# Altium schematic sheet carrier board Ultrafiltrasi.SchDoc
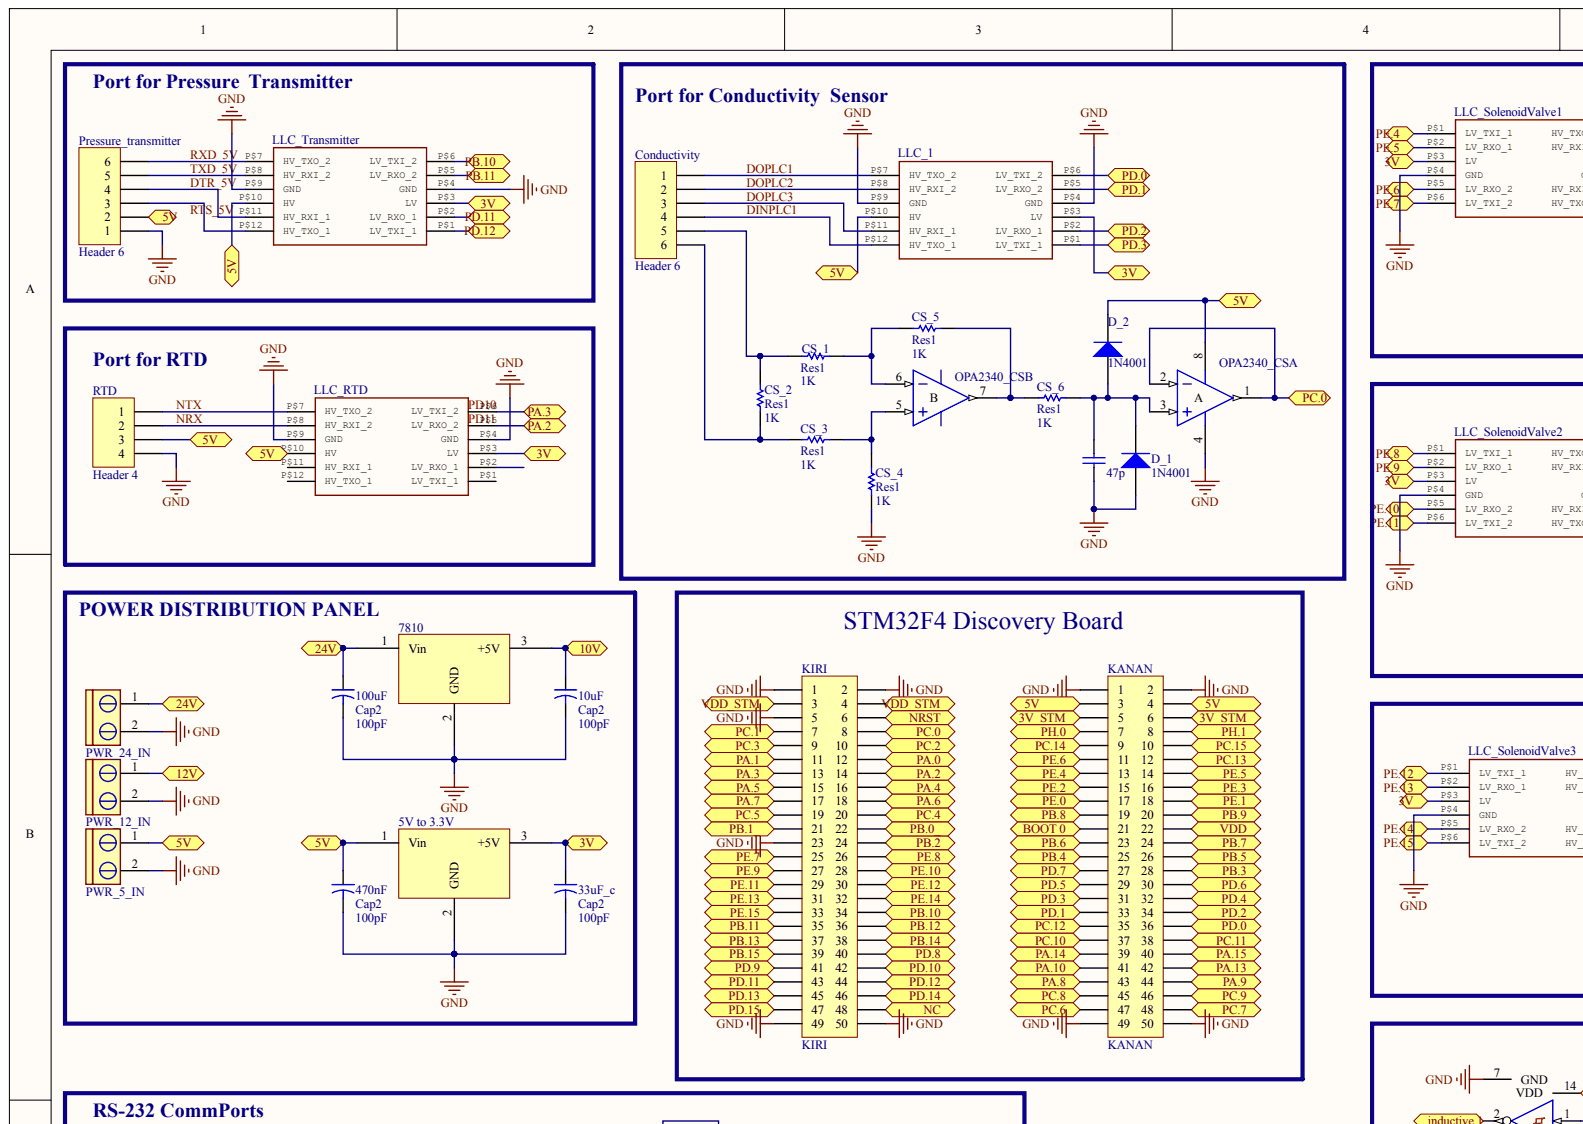
[diagram p0: carrier board Ultrafiltrasi.SchDoc, top left]
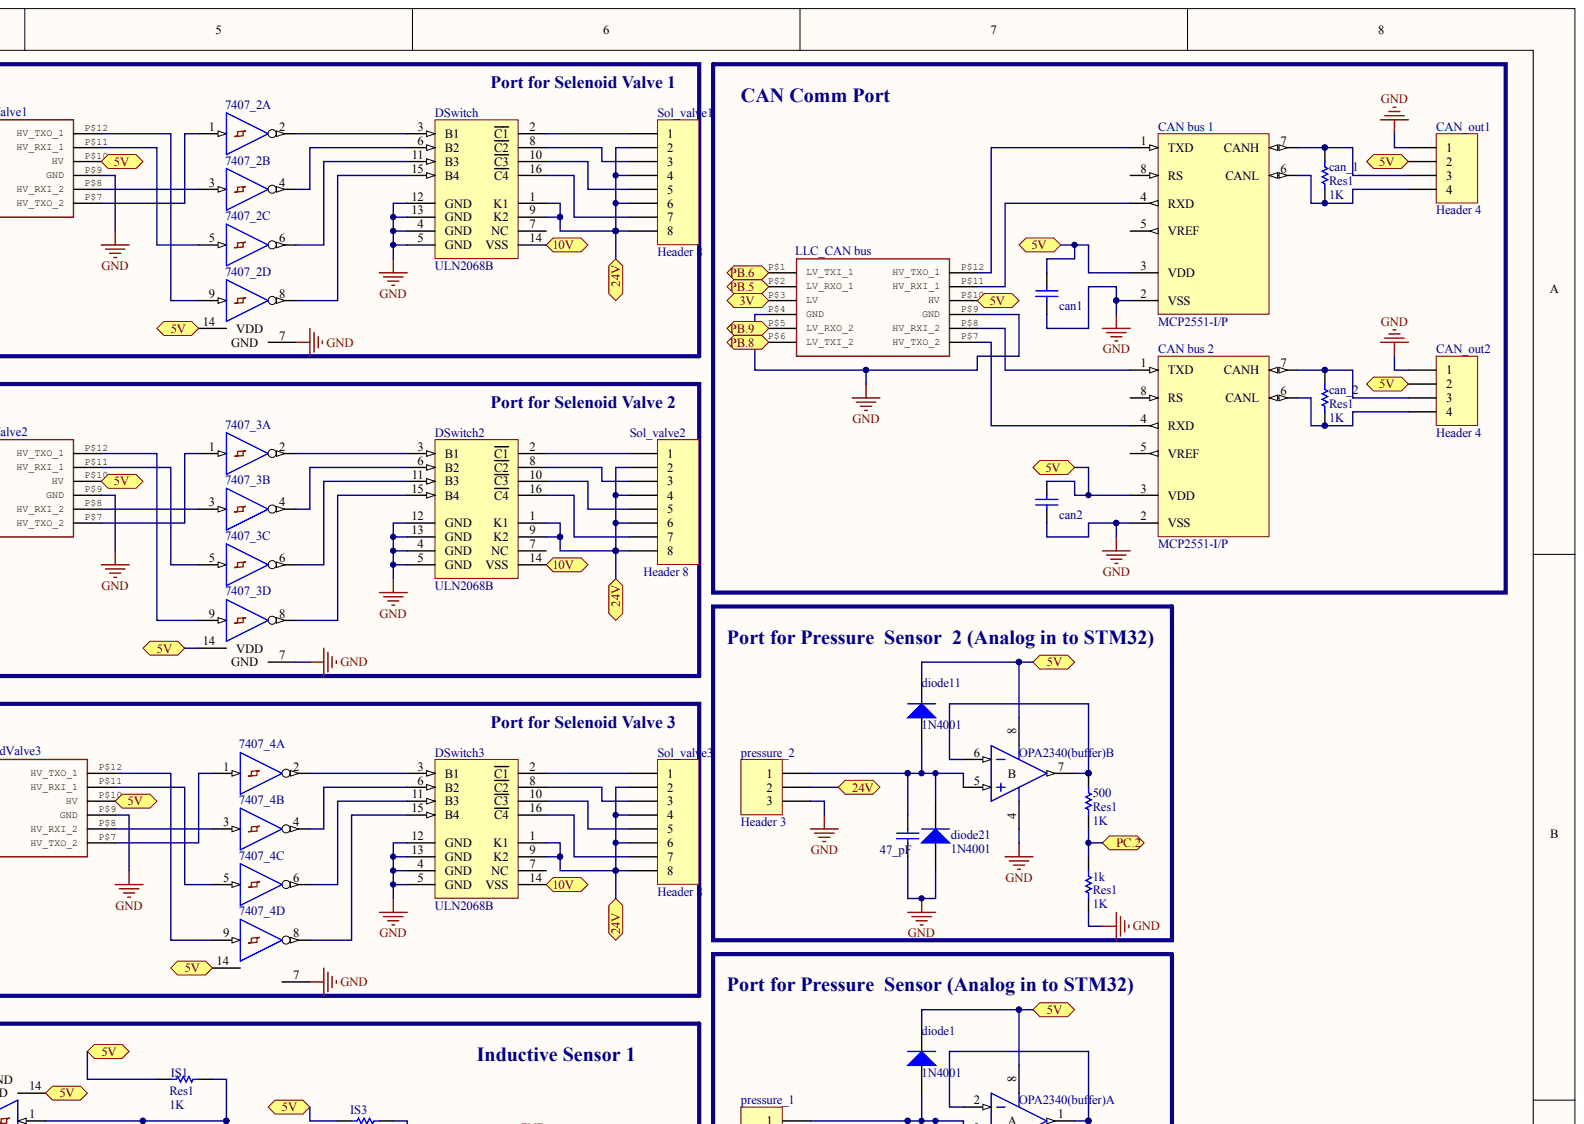
[diagram p1: carrier board Ultrafiltrasi.SchDoc, top right]
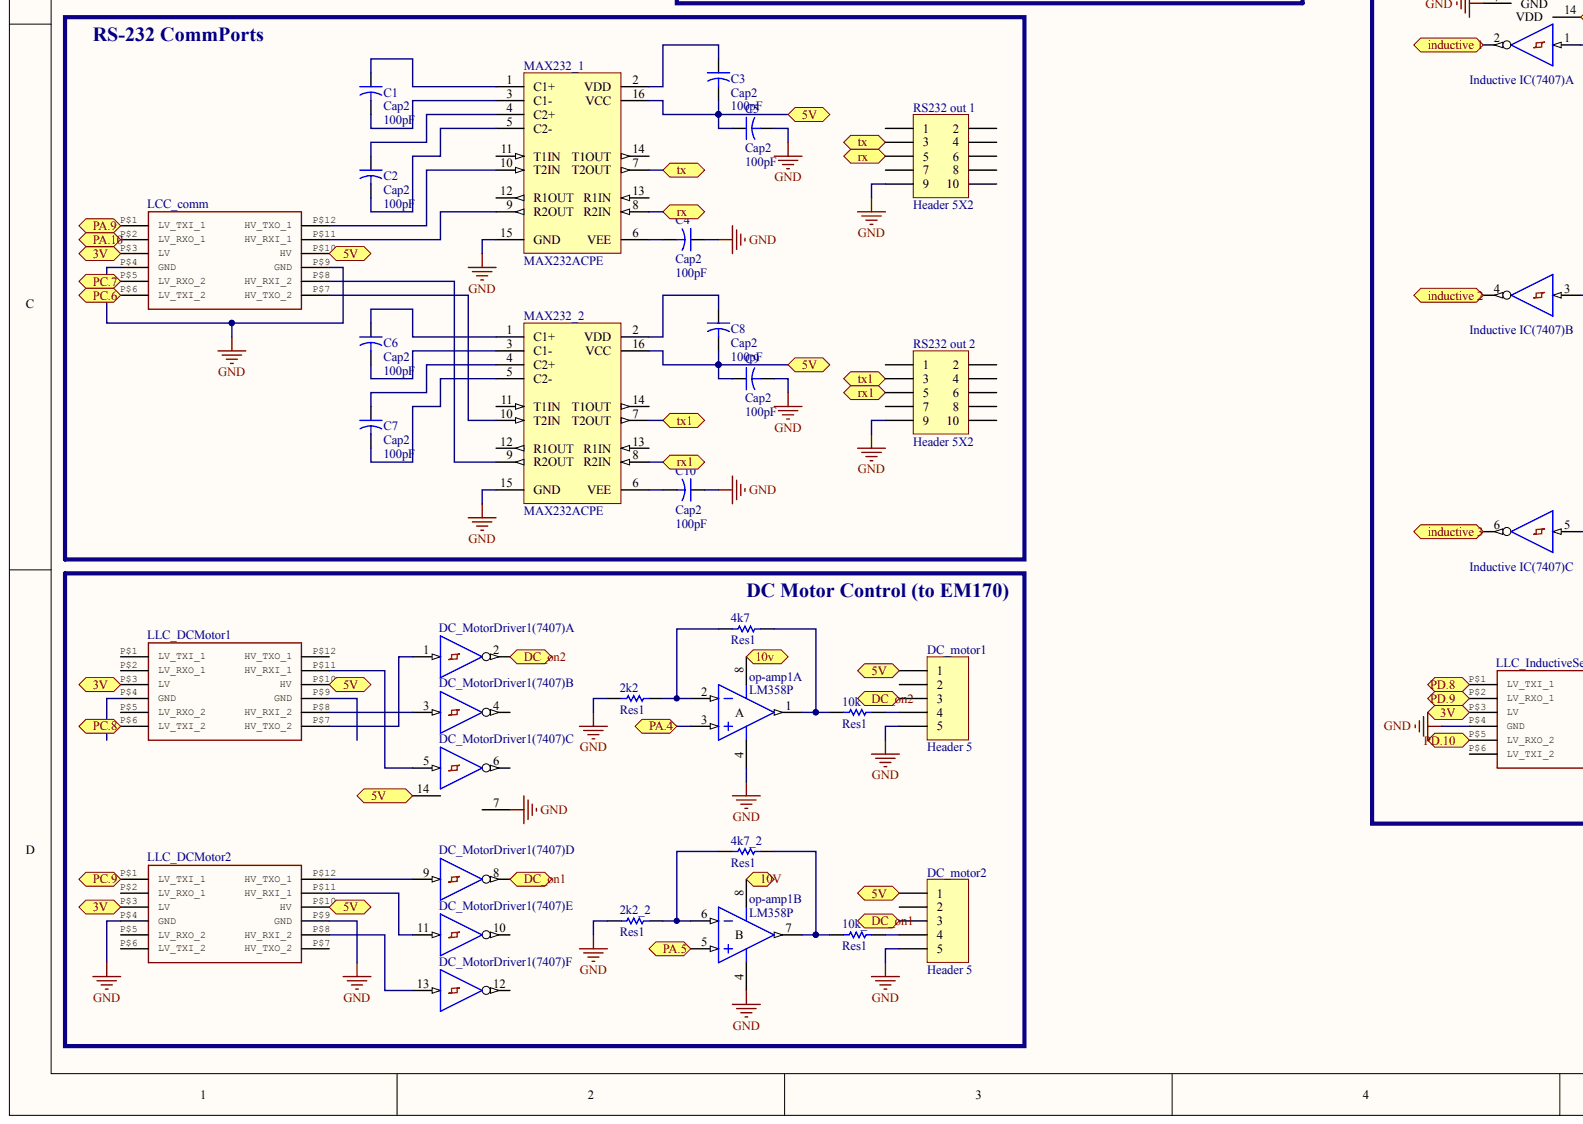
[diagram p2: carrier board Ultrafiltrasi.SchDoc, bottom left]
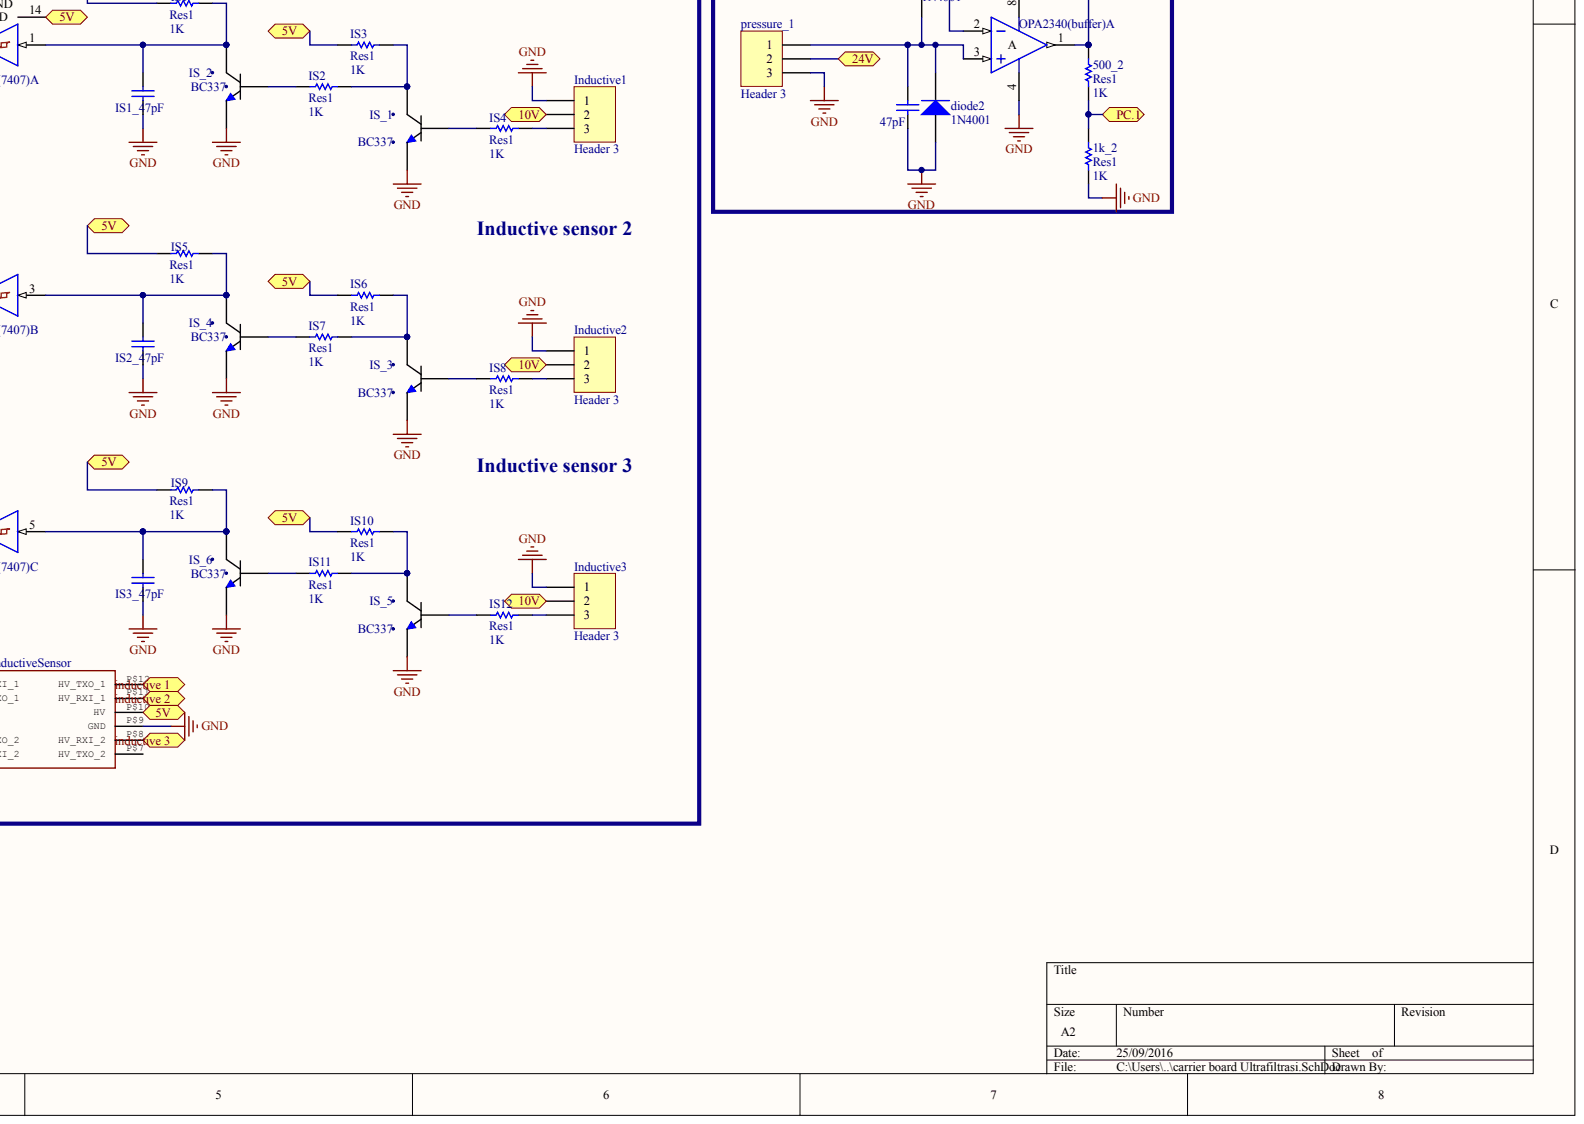
[diagram p3: carrier board Ultrafiltrasi.SchDoc, bottom right]

## Parts
1k  Res1  value 1K
1k_2  Res1  value 1K
2k2  Res1
2k2_2  Res1
4k7  Res1
4k7_2  Res1
5V_to_3.3V  7805  (value not drawn)
10k  Res1  value 10k
10k_2  Res1
10uF  Cap2  value 100pF
33uF_c  Cap2  value 100pF
47_pF  Cap  (value not drawn)
47p  Cap  (value not drawn)
47pF  Cap  (value not drawn)
100uF  Cap2  value 100pF
470nF  Cap2  value 100pF
500  Res1  value 1K
500_2  Res1  value 1K
7407_2  7407  (parts A,B,C,D)
7407_3  7407  (parts A,B,C,D)
7407_4  7407  (parts A,B,C,D)
7810  7805  (value not drawn)
C1  Cap2  value 100pF
C2  Cap2  value 100pF
C3  Cap2  value 100pF
C4  Cap2  value 100pF
C5  Cap2  value 100pF
C6  Cap2  value 100pF
C7  Cap2  value 100pF
C8  Cap2  value 100pF
C9  Cap2  value 100pF
C10  Cap2  value 100pF
can1  Cap  (value not drawn)
can2  Cap  (value not drawn)
CAN_bus_1  MCP2551-I/P
CAN_bus_2  MCP2551-I/P
can_1  Res1  value 1K
can_2  Res1  value 1K
CAN_out1  Header 4
CAN_out2  Header 4
Conductivity  Header 6
CS_1  Res1  value 1K
CS_2  Res1  value 1K
CS_3  Res1  value 1K
CS_4  Res1  value 1K
CS_5  Res1  value 1K
CS_6  Res1  value 1K
D_1  1N4001
D_2  1N4001
DC_motor1  Header 5
DC_motor2  Header 5
DC_MotorDriver1(7407)  SN74LS14N  (value not drawn)  (parts A,B,C,D,E,F)
diode1  1N4001
diode2  1N4001
diode11  1N4001
diode21  1N4001
DSwitch  ULN2068B
DSwitch2  ULN2068B
DSwitch3  ULN2068B
Inductive1  Header 3
Inductive2  Header 3
Inductive3  Header 3
Inductive_IC(7407)
IS1  Res1  value 1K
IS1_47pF  Cap  (value not drawn)
IS2  Res1  value 1K
IS2_47pF  Cap  (value not drawn)
IS3  Res1
IS3_47pF  Cap  (value not drawn)
IS4  Res1  value 1K
IS5  Res1  value 1K
IS6  Res1
IS7  Res1  value 1K
IS8  Res1  value 1K
IS9  Res1  value 1K
IS10  Res1  value 1K
IS11  Res1  value 1K
IS12  Res1  value 1K
IS_1  BC337
IS_2  BC337
IS_3  BC337
IS_4  BC337
IS_5  BC337
IS_6  BC337
KANAN  KANAN
KIRI  KIRI
LCC_comm  Logic Level Converter (3.3v - 5v) / https://www.sparkfun.com/products/8745  (value not drawn)
LLC_1  Logic Level Converter (3.3v - 5v) / https://www.sparkfun.com/products/8745  (value not drawn)
LLC_CAN_bus  Logic Level Converter (3.3v - 5v) / https://www.sparkfun.com/products/8745  (value not drawn)
LLC_DCMotor1  Logic Level Converter (3.3v - 5v) / https://www.sparkfun.com/products/8745  (value not drawn)
LLC_DCMotor2  Logic Level Converter (3.3v - 5v) / https://www.sparkfun.com/products/8745  (value not drawn)
LLC_InductiveSensor  Logic Level Converter (3.3v - 5v) / https://www.sparkfun.com/products/8745  (value not drawn)
LLC_RTD  Logic Level Converter (3.3v - 5v) / https://www.sparkfun.com/products/8745  (value not drawn)
LLC_SolenoidValve1  Logic Level Converter (3.3v - 5v) / https://www.sparkfun.com/products/8745  (value not drawn)
LLC_SolenoidValve2  Logic Level Converter (3.3v - 5v) / https://www.sparkfun.com/products/8745  (value not drawn)
LLC_SolenoidValve3  Logic Level Converter (3.3v - 5v) / https://www.sparkfun.com/products/8745  (value not drawn)
LLC_Transmitter  Logic Level Converter (3.3v - 5v) / https://www.sparkfun.com/products/8745  (value not drawn)
MAX232_1  MAX232ACPE
MAX232_2  MAX232ACPE
op-amp1  LM358P  (parts A,B)
OPA2340(buffer)  LM358P  (value not drawn)  (parts A,B)
OPA2340_CS  LM358P  (value not drawn)  (parts A,B)
pressure_1  Header 3
pressure_2  Header 3
Pressure_transmitter  Header 6
PWR_5_IN  CON2 pin blue  (value not drawn)
PWR_12_IN  CON2 pin blue  (value not drawn)
PWR_24_IN  CON2 pin blue  (value not drawn)
RS232_out_1  Header 5X2
RS232_out_2  Header 5X2
RTD  Header 4
Sol_valve1  Header 8
Sol_valve2  Header 8
Sol_valve3  Header 8

## Ports
3V, 3V_STM, 5V, 10V, 12V, 24V, BOOT 0, DC_ON1, DC_ON2, INDUCTIVE 1, INDUCTIVE 2, INDUCTIVE 3, NC, NRST, PA.0, PA.1, PA.2, PA.3, PA.4, PA.5, PA.6, PA.7, PA.8, PA.9, PA.10, PA.13, PA.14, PA.15, PB.0_, PB.1_, PB.2, PB.3, PB.4, PB.5, PB.6, PB.7, PB.8, PB.9, PB.10, PB.11, PB.12, PB.13, PB.14, PB.15, PC.0, PC.1, PC.2, PC.3, PC.4, PC.5, PC.6, PC.7, PC.8, PC.9, PC.10, PC.11, PC.12, PC.13, PC.14, PC.15, PD.0, PD.1, PD.2, PD.3, PD.4, PD.5, PD.6, PD.7, PD.8, PD.9, PD.10, PD.11, PD.12, PD.13, PD.14, PD.15, PE.0, PE.1, PE.2, PE.3, PE.4, PE.5, PE.6, PE.7, PE.8, PE.9, PE.10, PE.11, PE.12, PE.13, PE.14, PE.15, PH.0, PH.1, RX, RX1, TX, TX1, VDD, VDD_STM

## Nets
GND: 1k.1 1k_2.1 2k2.1 2k2_2.1 5V_to_3.3V.2 10uF.2 33uF_c.2 47_pF.1 47p.1 47pF.1 100uF.2 470nF.2 7407_2.7(GND) 7407_3.7(GND) 7407_4.7 7810.2 C4.1 C5.2 C9.2 C10.1 can1.1 can2.1 CAN_bus_1.2(VSS) CAN_bus_2.2(VSS) CAN_out1.1 CAN_out2.1 CS_4.1 D_1.1 DC_motor1.5 DC_motor2.5 DC_MotorDriver1(7407).7 diode2.1 diode21.1 DSwitch.4(GND) DSwitch.5(GND) DSwitch.12(GND) DSwitch.13(GND) DSwitch2.4(GND) DSwitch2.5(GND) DSwitch2.12(GND) DSwitch2.13(GND) DSwitch3.4(GND) DSwitch3.5(GND) DSwitch3.12(GND) DSwitch3.13(GND) Inductive1.1 Inductive2.1 Inductive3.1 Inductive_IC(7407).7 IS1_47pF.1 IS2_47pF.1 IS3_47pF.1 IS_1.3 IS_2.3 IS_3.3 IS_4.3 IS_5.3 IS_6.3 KANAN.1 KANAN.2 KANAN.49 KANAN.50 KIRI.1 KIRI.2 KIRI.5 KIRI.23 KIRI.49 KIRI.50 LCC_comm.P$4(GND) LCC_comm.P$9(GND) LLC_1.P$4(GND) LLC_1.P$9(GND) LLC_CAN_bus.P$4(GND) LLC_CAN_bus.P$9(GND) LLC_DCMotor2.P$4(GND) LLC_DCMotor2.P$9(GND) LLC_InductiveSensor.P$4 LLC_InductiveSensor.P$9 LLC_RTD.P$4(GND) LLC_RTD.P$9(GND) LLC_SolenoidValve1.P$4(GND) LLC_SolenoidValve1.P$9(GND) LLC_SolenoidValve2.P$4(GND) LLC_SolenoidValve2.P$9(GND) LLC_SolenoidValve3.P$4(GND) LLC_SolenoidValve3.P$9(GND) LLC_Transmitter.P$4(GND) LLC_Transmitter.P$9(GND) MAX232_1.15(GND) MAX232_2.15(GND) op-amp1.4 OPA2340(buffer).4 OPA2340_CS.4 pressure_1.3 pressure_2.3 Pressure_transmitter.1 PWR_5_IN.2 PWR_12_IN.2 PWR_24_IN.2 RS232_out_1.9 RS232_out_2.9 RTD.4
VDD: 7407_2.14(VDD) 7407_3.14(VDD) 7407_4.14 DC_MotorDriver1(7407).14 Inductive_IC(7407).14
3V: 5V_to_3.3V.3 33uF_c.1 LCC_comm.P$3(LV) LLC_1.P$3(LV) LLC_CAN_bus.P$3(LV) LLC_DCMotor1.P$3(LV) LLC_DCMotor2.P$3 LLC_InductiveSensor.P$3(LV) LLC_RTD.P$3(LV) LLC_SolenoidValve1.P$3(LV) LLC_SolenoidValve2.P$3(LV) LLC_SolenoidValve3.P$3(LV) LLC_Transmitter.P$3(LV) ; port 3V
3V_STM: KANAN.5 KANAN.6 ; port 3V_STM
5V: 5V_to_3.3V.1 470nF.1 7407_2.14(VDD) 7407_3.14(VDD) 7407_4.14 C3.2 C5.1 C8.2 C9.1 can1.2 can2.2 CAN_bus_1.3(VDD) CAN_bus_2.3(VDD) CAN_out1.2 CAN_out2.2 D_2.2 DC_motor1.1 DC_motor2.1 DC_MotorDriver1(7407).14 diode1.2 diode11.2 Inductive_IC(7407).14 IS1.1 IS3.1 IS5.1 IS6.1 IS9.1 IS10.1 KANAN.3 KANAN.4 LCC_comm.P$10(HV) LLC_1.P$10(HV) LLC_CAN_bus.P$10(HV) LLC_DCMotor1.P$10(HV) LLC_DCMotor2.P$10 LLC_InductiveSensor.P$10(HV) LLC_RTD.P$10(HV) LLC_SolenoidValve1.P$10(HV) LLC_SolenoidValve2.P$10(HV) LLC_SolenoidValve3.P$10(HV) LLC_Transmitter.P$10(HV) MAX232_1.16(VCC) MAX232_2.16(VCC) OPA2340(buffer).8 OPA2340_CS.8 Pressure_transmitter.2 PWR_5_IN.1 RTD.3 ; port 5V
10V: 10uF.1 7810.3 DSwitch.14(VSS) DSwitch2.14(VSS) DSwitch3.14(VSS) Inductive1.2 Inductive2.2 Inductive3.2 op-amp1.8 ; port 10V
12V: PWR_12_IN.1 ; port 12V
24V: 100uF.1 7810.1 DSwitch.1(K1) DSwitch.9(K2) DSwitch2.1(K1) DSwitch2.9(K2) DSwitch3.1(K1) DSwitch3.9(K2) pressure_1.2 pressure_2.2 PWR_24_IN.1 Sol_valve1.2 Sol_valve1.4 Sol_valve1.6 Sol_valve1.8 Sol_valve2.2 Sol_valve2.4 Sol_valve2.6 Sol_valve2.8 Sol_valve3.2 Sol_valve3.4 Sol_valve3.6 Sol_valve3.8 ; port 24V
BOOT 0: KANAN.21 ; port BOOT 0
DINPLC1: Conductivity.4 LLC_1.P$12(HV_TXO_1)
DOPLC1: Conductivity.1 LLC_1.P$7(HV_TXO_2)
DOPLC2: Conductivity.2 LLC_1.P$8(HV_RXI_2)
DOPLC3: Conductivity.3 LLC_1.P$11(HV_RXI_1)
DTR_5V: LLC_Transmitter.P$11(HV_RXI_1) Pressure_transmitter.4
NC: KIRI.48 ; port NC
NRST: KIRI.6 ; port NRST
NRX: LLC_RTD.P$8(HV_RXI_2) RTD.2
NTX: LLC_RTD.P$7(HV_TXO_2) RTD.1
PA.0: KIRI.12 ; port PA.0
PA.1: KIRI.11 ; port PA.1
PA.2: KIRI.14 LLC_RTD.P$5(LV_RXO_2) ; port PA.2
PA.3: KIRI.13 LLC_RTD.P$6(LV_TXI_2) ; port PA.3
PA.4: KIRI.16 op-amp1.3 ; port PA.4
PA.5: KIRI.15 op-amp1.5 ; port PA.5
PA.6: KIRI.18 ; port PA.6
PA.7: KIRI.17 ; port PA.7
PA.8: KANAN.43 ; port PA.8
PA.9: KANAN.44 LCC_comm.P$1(LV_TXI_1) ; port PA.9
PA.10: KANAN.41 LCC_comm.P$2(LV_RXO_1) ; port PA.10
PA.13: KANAN.42 ; port PA.13
PA.14: KANAN.39 ; port PA.14
PA.15: KANAN.40 ; port PA.15
PB.0_: KIRI.22 ; port PB.0_
PB.2: KIRI.24 ; port PB.2
PB.3: KANAN.28 ; port PB.3
PB.4: KANAN.25 ; port PB.4
PB.5: KANAN.26 LLC_CAN_bus.P$2(LV_RXO_1) ; port PB.5
PB.6: KANAN.23 LLC_CAN_bus.P$1(LV_TXI_1) ; port PB.6
PB.7: KANAN.24 ; port PB.7
PB.8: KANAN.19 LLC_CAN_bus.P$6(LV_TXI_2) ; port PB.8
PB.9: KANAN.20 LLC_CAN_bus.P$5(LV_RXO_2) ; port PB.9
PB.11: KIRI.35 LLC_Transmitter.P$5(LV_RXO_2) ; port PB.11
PB.12: KIRI.36 ; port PB.12
PB.13: KIRI.37 ; port PB.13
PB.14: KIRI.38 ; port PB.14
PB.15: KIRI.39 ; port PB.15
PC.0: KIRI.8 OPA2340_CS.1 OPA2340_CS.2 ; port PC.0
PC.1: 1k_2.2 500_2.1 KIRI.7 ; port PC.1
PC.2: 1k.2 500.1 KIRI.10 ; port PC.2
PC.3: KIRI.9 ; port PC.3
PC.4: KIRI.20 ; port PC.4
PC.5: KIRI.19 ; port PC.5
PC.6: KANAN.47 LCC_comm.P$6(LV_TXI_2) ; port PC.6
PC.7: KANAN.48 LCC_comm.P$5(LV_RXO_2) ; port PC.7
PC.8: KANAN.45 LLC_DCMotor1.P$6 ; port PC.8
PC.9: KANAN.46 LLC_DCMotor2.P$1(LV_TXI_1) ; port PC.9
PC.10: KANAN.37 ; port PC.10
PC.11: KANAN.38 ; port PC.11
PC.12: KANAN.35 ; port PC.12
PC.13: KANAN.12 ; port PC.13
PC.14: KANAN.9 ; port PC.14
PC.15: KANAN.10 ; port PC.15
PD.0: KANAN.36 LLC_1.P$6(LV_TXI_2) ; port PD.0
PD.1: KANAN.33 LLC_1.P$5(LV_RXO_2) ; port PD.1
PD.2: KANAN.34 LLC_1.P$2(LV_RXO_1) ; port PD.2
PD.3: KANAN.31 LLC_1.P$1(LV_TXI_1) ; port PD.3
PD.4: KANAN.32 ; port PD.4
PD.5: KANAN.29 ; port PD.5
PD.6: KANAN.30 ; port PD.6
PD.7: KANAN.27 ; port PD.7
PD.8: KIRI.40 LLC_InductiveSensor.P$1(LV_TXI_1) ; port PD.8
PD.9: KIRI.41 LLC_InductiveSensor.P$2(LV_RXO_1) ; port PD.9
PD.10: KIRI.42 LLC_InductiveSensor.P$5(LV_RXO_2) ; port PD.10
PD.11: KIRI.43 LLC_Transmitter.P$2(LV_RXO_1) ; port PD.11
PD.12: KIRI.44 LLC_Transmitter.P$1(LV_TXI_1) ; port PD.12
PD.13: KIRI.45 ; port PD.13
PD.14: KIRI.46 ; port PD.14
PD.15: KIRI.47 ; port PD.15
PE.0: KANAN.17 ; port PE.0
PE.1: KANAN.18 ; port PE.1
PE.2: KANAN.15 ; port PE.2
PE.3: KANAN.16 ; port PE.3
PE.4: KANAN.13 LLC_SolenoidValve1.P$1(LV_TXI_1) ; port PE.4
PE.5: KANAN.14 LLC_SolenoidValve1.P$2(LV_RXO_1) ; port PE.5
PE.6: KANAN.11 LLC_SolenoidValve1.P$5(LV_RXO_2) ; port PE.6
PE.7: KIRI.25 LLC_SolenoidValve1.P$6(LV_TXI_2) ; port PE.7
PE.8: KIRI.26 LLC_SolenoidValve2.P$1(LV_TXI_1) ; port PE.8
PE.9: KIRI.27 LLC_SolenoidValve2.P$2(LV_RXO_1) ; port PE.9
PE.10: KIRI.28 LLC_SolenoidValve2.P$5(LV_RXO_2) ; port PE.10
PE.11: KIRI.29 LLC_SolenoidValve2.P$6(LV_TXI_2) ; port PE.11
PE.12: KIRI.30 LLC_SolenoidValve3.P$1(LV_TXI_1) ; port PE.12
PE.13: KIRI.31 LLC_SolenoidValve3.P$2(LV_RXO_1) ; port PE.13
PE.14: KIRI.32 LLC_SolenoidValve3.P$5(LV_RXO_2) ; port PE.14
PE.15: KIRI.33 LLC_SolenoidValve3.P$6(LV_TXI_2) ; port PE.15
PH.0: KANAN.7 ; port PH.0
PH.1: KANAN.8 ; port PH.1
RXD_5V: LLC_Transmitter.P$7(HV_TXO_2) Pressure_transmitter.6
TXD_5V: LLC_Transmitter.P$8(HV_RXI_2) Pressure_transmitter.5
VDD_STM: KIRI.3 KIRI.4 ; port VDD_STM
Net2k2_2: 2k2.2 4k7.1 op-amp1.2
Net2k2_2_2: 2k2_2.2 4k7_2.1 op-amp1.6
Net4k7_2: 4k7.2 10k.1 op-amp1.1
Net4k7_2_2: 4k7_2.2 10k_2.1 op-amp1.7
Net10k_2: 10k.2 DC_motor1.4
Net10k_2_2: 10k_2.2 DC_motor2.4
Net47_pF_2: 47_pF.2 diode11.1 diode21.2 OPA2340(buffer).5 pressure_2.1
Net47p_2: 47p.2 CS_6.2 D_1.2 D_2.1 OPA2340_CS.3
Net47pF_2: 47pF.2 diode1.1 diode2.2 OPA2340(buffer).3 pressure_1.1
Net500_2: 500.2 OPA2340(buffer).6 OPA2340(buffer).7
Net500_2_2: 500_2.2 OPA2340(buffer).1 OPA2340(buffer).2
Net7407_2_1: 7407_2.1 LLC_SolenoidValve1.P$7(HV_TXO_2)
Net7407_2_2: 7407_2.2 DSwitch.3(B1)
Net7407_2_3: 7407_2.3 LLC_SolenoidValve1.P$8(HV_RXI_2)
Net7407_2_4: 7407_2.4 DSwitch.6(B2)
Net7407_2_5: 7407_2.5 LLC_SolenoidValve1.P$11(HV_RXI_1)
Net7407_2_6: 7407_2.6 DSwitch.11(B3)
Net7407_2_8: 7407_2.8 DSwitch.15(B4)
Net7407_2_9: 7407_2.9 LLC_SolenoidValve1.P$12(HV_TXO_1)
Net7407_3_1: 7407_3.1 LLC_SolenoidValve2.P$7(HV_TXO_2)
Net7407_3_2: 7407_3.2 DSwitch2.3(B1)
Net7407_3_3: 7407_3.3 LLC_SolenoidValve2.P$8(HV_RXI_2)
Net7407_3_4: 7407_3.4 DSwitch2.6(B2)
Net7407_3_5: 7407_3.5 LLC_SolenoidValve2.P$11(HV_RXI_1)
Net7407_3_6: 7407_3.6 DSwitch2.11(B3)
Net7407_3_8: 7407_3.8 DSwitch2.15(B4)
Net7407_3_9: 7407_3.9 LLC_SolenoidValve2.P$12(HV_TXO_1)
Net7407_4_1: 7407_4.1 LLC_SolenoidValve3.P$7(HV_TXO_2)
Net7407_4_2: 7407_4.2 DSwitch3.3(B1)
Net7407_4_3: 7407_4.3 LLC_SolenoidValve3.P$8(HV_RXI_2)
Net7407_4_4: 7407_4.4 DSwitch3.6(B2)
Net7407_4_5: 7407_4.5 LLC_SolenoidValve3.P$11(HV_RXI_1)
Net7407_4_6: 7407_4.6 DSwitch3.11(B3)
Net7407_4_8: 7407_4.8 DSwitch3.15(B4)
Net7407_4_9: 7407_4.9 LLC_SolenoidValve3.P$12(HV_TXO_1)
NetC1_1: C1.1 MAX232_1.1(C1+)
NetC1_2: C1.2 MAX232_1.3(C1-)
NetC2_1: C2.1 MAX232_1.4(C2+)
NetC2_2: C2.2 MAX232_1.5(C2-)
NetC3_1: C3.1 MAX232_1.2(VDD)
NetC4_2: C4.2 MAX232_1.6(VEE)
NetC6_1: C6.1 MAX232_2.1(C1+)
NetC6_2: C6.2 MAX232_2.3(C1-)
NetC7_1: C7.1 MAX232_2.4(C2+)
NetC7_2: C7.2 MAX232_2.5(C2-)
NetC8_1: C8.1 MAX232_2.2(VDD)
NetC10_2: C10.2 MAX232_2.6(VEE)
NetCAN bus 1_1: CAN_bus_1.1(TXD) LLC_CAN_bus.P$12(HV_TXO_1)
NetCAN bus 1_4: CAN_bus_1.4(RXD) LLC_CAN_bus.P$11(HV_RXI_1)
NetCAN bus 2_1: CAN_bus_2.1(TXD) LLC_CAN_bus.P$8(HV_RXI_2)
NetCAN bus 2_4: CAN_bus_2.4(RXD) LLC_CAN_bus.P$7(HV_TXO_2)
Netcan_1_1: CAN_bus_1.6(CANL) can_1.1 CAN_out1.4
Netcan_1_2: CAN_bus_1.7(CANH) can_1.2 CAN_out1.3
Netcan_2_1: CAN_bus_2.6(CANL) can_2.1 CAN_out2.4
Netcan_2_2: CAN_bus_2.7(CANH) can_2.2 CAN_out2.3
NetConductivity_5: Conductivity.5 CS_1.1 CS_2.2
NetConductivity_6: Conductivity.6 CS_2.1 CS_3.1
NetCS_1_2: CS_1.2 CS_5.1 OPA2340_CS.6
NetCS_3_2: CS_3.2 CS_4.2 OPA2340_CS.5
NetCS_5_2: CS_5.2 CS_6.1 OPA2340_CS.7
NetDC_motor1_3: DC_motor1.3 DC_MotorDriver1(7407).2 ; port DC_ON2
NetDC_motor2_3: DC_motor2.3 DC_MotorDriver1(7407).8 ; port DC_ON1
NetDC_MotorDriver1(7407)_1: DC_MotorDriver1(7407).1 LLC_DCMotor1.P$7
NetDC_MotorDriver1(7407)_3: DC_MotorDriver1(7407).3 LLC_DCMotor1.P$8(HV_RXI_2)
NetDC_MotorDriver1(7407)_5: DC_MotorDriver1(7407).5 LLC_DCMotor1.P$11(HV_RXI_1)
NetDC_MotorDriver1(7407)_9: DC_MotorDriver1(7407).9 LLC_DCMotor2.P$12(HV_TXO_1)
NetDC_MotorDriver1(7407)_11: DC_MotorDriver1(7407).11 LLC_DCMotor2.P$11(HV_RXI_1)
NetDC_MotorDriver1(7407)_13: DC_MotorDriver1(7407).13 LLC_DCMotor2.P$8(HV_RXI_2)
NetDSwitch2_2: DSwitch2.2(C1) Sol_valve2.1
NetDSwitch2_8: DSwitch2.8(C2) Sol_valve2.3
NetDSwitch2_10: DSwitch2.10(C3) Sol_valve2.5
NetDSwitch2_16: DSwitch2.16(C4) Sol_valve2.7
NetDSwitch3_2: DSwitch3.2(C1) Sol_valve3.1
NetDSwitch3_8: DSwitch3.8(C2) Sol_valve3.3
NetDSwitch3_10: DSwitch3.10(C3) Sol_valve3.5
NetDSwitch3_16: DSwitch3.16(C4) Sol_valve3.7
NetDSwitch_2: DSwitch.2(C1) Sol_valve1.1
NetDSwitch_8: DSwitch.8(C2) Sol_valve1.3
NetDSwitch_10: DSwitch.10(C3) Sol_valve1.5
NetDSwitch_16: DSwitch.16(C4) Sol_valve1.7
NetInductive1_3: Inductive1.3 IS4.2
NetInductive2_3: Inductive2.3 IS8.2
NetInductive3_3: Inductive3.3 IS12.2
NetInductive IC(7407)_1: Inductive_IC(7407).1 IS1.2 IS1_47pF.2 IS_2.1
NetInductive IC(7407)_2: Inductive_IC(7407).2 LLC_InductiveSensor.P$12(HV_TXO_1) ; port INDUCTIVE 1
NetInductive IC(7407)_3: Inductive_IC(7407).3 IS2_47pF.2 IS5.2 IS_4.1
NetInductive IC(7407)_4: Inductive_IC(7407).4 LLC_InductiveSensor.P$11(HV_RXI_1) ; port INDUCTIVE 2
NetInductive IC(7407)_5: Inductive_IC(7407).5 IS3_47pF.2 IS9.2 IS_6.1
NetInductive IC(7407)_6: Inductive_IC(7407).6 LLC_InductiveSensor.P$8(HV_RXI_2) ; port INDUCTIVE 3
NetIS2_1: IS2.1 IS_2.2
NetIS2_2: IS2.2 IS3.2 IS_1.1
NetIS4_1: IS4.1 IS_1.2
NetIS6_2: IS6.2 IS7.2 IS_3.1
NetIS7_1: IS7.1 IS_4.2
NetIS8_1: IS8.1 IS_3.2
NetIS10_2: IS10.2 IS11.2 IS_5.1
NetIS11_1: IS11.1 IS_6.2
NetIS12_1: IS12.1 IS_5.2
NetKANAN_22: KANAN.22 ; port VDD
NetKIRI_21: KIRI.21
NetKIRI_34: KIRI.34 LLC_Transmitter.P$6(LV_TXI_2)
NetLCC_comm_P$7: LCC_comm.P$7(HV_TXO_2) MAX232_2.10(T2IN)
NetLCC_comm_P$8: LCC_comm.P$8(HV_RXI_2) MAX232_2.9(R2OUT)
NetLCC_comm_P$11: LCC_comm.P$11(HV_RXI_1) MAX232_1.9(R2OUT)
NetLCC_comm_P$12: LCC_comm.P$12(HV_TXO_1) MAX232_1.10(T2IN)
NetLLC_Transmitter_P$12: LLC_Transmitter.P$12(HV_TXO_1) Pressure_transmitter.3
NetMAX232_1_7: MAX232_1.7(T2OUT) RS232_out_1.3 ; port TX
NetMAX232_1_8: MAX232_1.8(R2IN) RS232_out_1.5 ; port RX
NetMAX232_2_7: MAX232_2.7(T2OUT) RS232_out_2.3 ; port TX1
NetMAX232_2_8: MAX232_2.8(R2IN) RS232_out_2.5 ; port RX1
PD10:
PD11:
RTS_5V:

Not connected: CAN_bus_1 5(VREF) 8(RS); CAN_bus_2 5(VREF) 8(RS); DC_motor1 2; DC_motor2 2; DC_MotorDriver1(7407) 4 6 10 12; DSwitch 7(NC); DSwitch2 7(NC); DSwitch3 7(NC); LLC_DCMotor1 P$1(LV_TXI_1) P$2(LV_RXO_1) P$4(GND) P$5(LV_RXO_2) P$9(GND) P$12(HV_TXO_1); LLC_DCMotor2 P$2(LV_RXO_1) P$5(LV_RXO_2) P$6 P$7; LLC_InductiveSensor P$6(LV_TXI_2) P$7(HV_TXO_2); LLC_RTD P$1(LV_TXI_1) P$2(LV_RXO_1) P$11(HV_RXI_1) P$12(HV_TXO_1); MAX232_1 11(T1IN) 12(R1OUT) 13(R1IN) 14(T1OUT); MAX232_2 11(T1IN) 12(R1OUT) 13(R1IN) 14(T1OUT); RS232_out_1 1 2 4 6 7 8 10; RS232_out_2 1 2 4 6 7 8 10

## Library data of the parts
2k2 2k2_2 4k7 4k7_2 10k_2 CS_5 IS3 IS6 = (no symbol name): Value: 1K; Published: 8-Jun-2000; LatestRevisionDate: 17-Jul-2002; LatestRevisionNote: Re-released for DXP Platform.; PackageReference: AXIAL-0.3; PackageDescription: Axial Device, Thru-Hole; 2 Leads; 0.3 in Pin Spacing; Publisher: Altium Limited
47_pF 47p 47pF can1 can2 IS1_47pF IS2_47pF IS3_47pF = (no symbol name): Value: 100nF
1k 1k_2 5V_to_3.3V 10k 10uF 33uF_c 100uF 470nF 500 500_2 7407_2-7407_4 7810 C1-C10 can_1 can_2 CAN_bus_1 CAN_bus_2 CAN_out1 CAN_out2 Conductivity CS_1-CS_4 CS_6 D_1 D_2 DC_motor1 DC_motor2 DC_MotorDriver1(7407) diode1 diode2 diode11 diode21 DSwitch DSwitch2 DSwitch3 Inductive1-Inductive3 IS1 IS2 IS4 IS5 IS7-IS12 IS_1-IS_6 KANAN KIRI LCC_comm LLC_1 LLC_CAN_bus LLC_DCMotor1 LLC_DCMotor2 LLC_InductiveSensor LLC_RTD LLC_SolenoidValve1-LLC_SolenoidValve3 LLC_Transmitter MAX232_1 MAX232_2 op-amp1 OPA2340(buffer) OPA2340_CS pressure_1 pressure_2 Pressure_transmitter PWR_5_IN PWR_12_IN PWR_24_IN RS232_out_1 RS232_out_2 RTD Sol_valve1-Sol_valve3 = (no symbol name)
  1k 1k_2 10k 500 500_2 can_1 can_2 CS_1-CS_4 CS_6 IS1 IS2 IS4 IS5 IS7-IS12: Published: 8-Jun-2000; LatestRevisionDate: 17-Jul-2002; LatestRevisionNote: Re-released for DXP Platform.; PackageReference: AXIAL-0.3; PackageDescription: Axial Device, Thru-Hole; 2 Leads; 0.3 in Pin Spacing
  10uF 33uF_c 100uF 470nF C1-C10: Published: 23-Sep-2002; PackageReference: CAPR5-4X5; PackageDescription: Capacitor; 2 Leads
  7407_2-7407_4 DC_MotorDriver1(7407): Published: 15-Apr-2002; DatasheetVersion: 18-Oct-1996; PackageVersion: 1995; PackageReference: 646-06; PackageDescription: DIP; 14 Leads; Row Spacing 7.62 mm; Pitch 2.54 mm; ComponentLink1Description: Manufacturer Link; ComponentLink1URL: http://www.onsemi.com/; ComponentLink2Description: Datasheet; ComponentLink2URL: http://www.onsemi.com/pub/Collateral/SN74LS14-D.PDF
  CAN_bus_1 CAN_bus_2: Published: 29-Jan-2009; DatasheetVersion: revE, Jan-2007; PackageVersion: revBB, Aug-2009; PackageReference: PDIP300-P8; PackageDescription: 8-Lead Plastic Dual In-Line (P) - 300 mil Body [PDIP]; Supplier 1: Digi-Key; Supplier Part Number 1: MCP2551-I/P-ND; Supplier 2: Farnell; Supplier Part Number 2: 1292240; Code_JEDEC: MS-001; ComponentLink1Description: Datasheet; ComponentLink1URL: http://ww1.microchip.com/downloads/en/DeviceDoc/21667E.pdf; ComponentLink2Description: Packaging Specification; ComponentLink2URL: http://www.microchip.com/stellent/groups/techpub_sg/documents/packagingspec/en012702.pdf; ComponentLink3Description: Microchip.com Home; ComponentLink3URL: http://www.microchip.com/
  CAN_out1 CAN_out2 Conductivity DC_motor1 DC_motor2 Inductive1-Inductive3 KANAN KIRI pressure_1 pressure_2 Pressure_transmitter RS232_out_1 RS232_out_2 RTD Sol_valve1-Sol_valve3: LatestRevisionDate: 17-Jul-2002; LatestRevisionNote: Re-released for DXP Platform.
  D_1 D_2 diode1 diode2 diode11 diode21: Published: 8-Jun-2000; LatestRevisionDate: 17-Jul-2002; LatestRevisionNote: Re-released for DXP Platform.; DatasheetVersion: 1998; PackageVersion: Aug-1999; PackageReference: DO-41; PackageDescription: Diode, Axial; Body 5.2 x 2.7 mm (LxDia. max), Lead Dia. 0.86 mm (max); Code_JEDEC: DO-204-AL; ComponentLink1Description: Manufacturer Link; ComponentLink1URL: http://www.fairchildsemi.com/; ComponentLink2Description: Datasheet; ComponentLink2URL: http://www.fairchildsemi.com/ds/1N%2F1N4001.pdf
  DSwitch DSwitch2 DSwitch3: Published: 17-Jul-2002; LatestRevisionDate: 06-Jun-2005; LatestRevisionNote: Stylized 3D Model Added.; DatasheetVersion: 1988; PackageReference: 648C-02; PackageDescription: DIP; 16 Leads; Row Spacing 7.62 mm; Pitch 2.54 mm
  MAX232_1 MAX232_2: Published: 16-Jan-2001; LatestRevisionDate: 29-May-2009; LatestRevisionNote: Component Body Added.; PackageVersion: 1997; PackageReference: PE16A; PackageDescription: DIP, 16-Pin, Row Spacing 7.62 mm, Pitch 2.54 mm; Code_JEDEC: MS-001-BB; ComponentLink1Description: Manufacturer Link; ComponentLink1URL: http://www.maxim-ic.com/; ComponentLink2Description: Datasheet; ComponentLink2URL: http://pdfserv.maxim-ic.com/en/ds/MAX220-MAX249.pdf
  op-amp1 OPA2340(buffer) OPA2340_CS: Published: 16-Jan-2001; LatestRevisionDate: 04-Mar-2005; LatestRevisionNote: Stylized 3D Model Added.; DatasheetVersion: Jul-1998; PackageVersion: Jun-1999; PackageReference: P008; PackageDescription: DIP; 8 Leads; Row Spacing 7.62 mm; Pitch 2.54 mm; Code_JEDEC: MO-001-AN; ComponentLink1Description: Manufacturer Link; ComponentLink1URL: http://www.ti.com/; ComponentLink2Description: Datasheet; ComponentLink2URL: http://www-s.ti.com/sc/ds/lm358.pdf
  1k 1k_2 10k 10uF 33uF_c 100uF 470nF 500 500_2 7407_2-7407_4 C1-C10 can_1 can_2 CAN_bus_1 CAN_bus_2 CAN_out1 CAN_out2 Conductivity CS_1-CS_4 CS_6 D_1 D_2 DC_motor1 DC_motor2 DC_MotorDriver1(7407) diode1 diode2 diode11 diode21 DSwitch DSwitch2 DSwitch3 Inductive1-Inductive3 IS1 IS2 IS4 IS5 IS7-IS12 KANAN KIRI MAX232_1 MAX232_2 op-amp1 OPA2340(buffer) OPA2340_CS pressure_1 pressure_2 Pressure_transmitter RS232_out_1 RS232_out_2 RTD Sol_valve1-Sol_valve3: Publisher: Altium Limited
